# Altium schematic sheet Sch-QWA59_Power.SchDoc
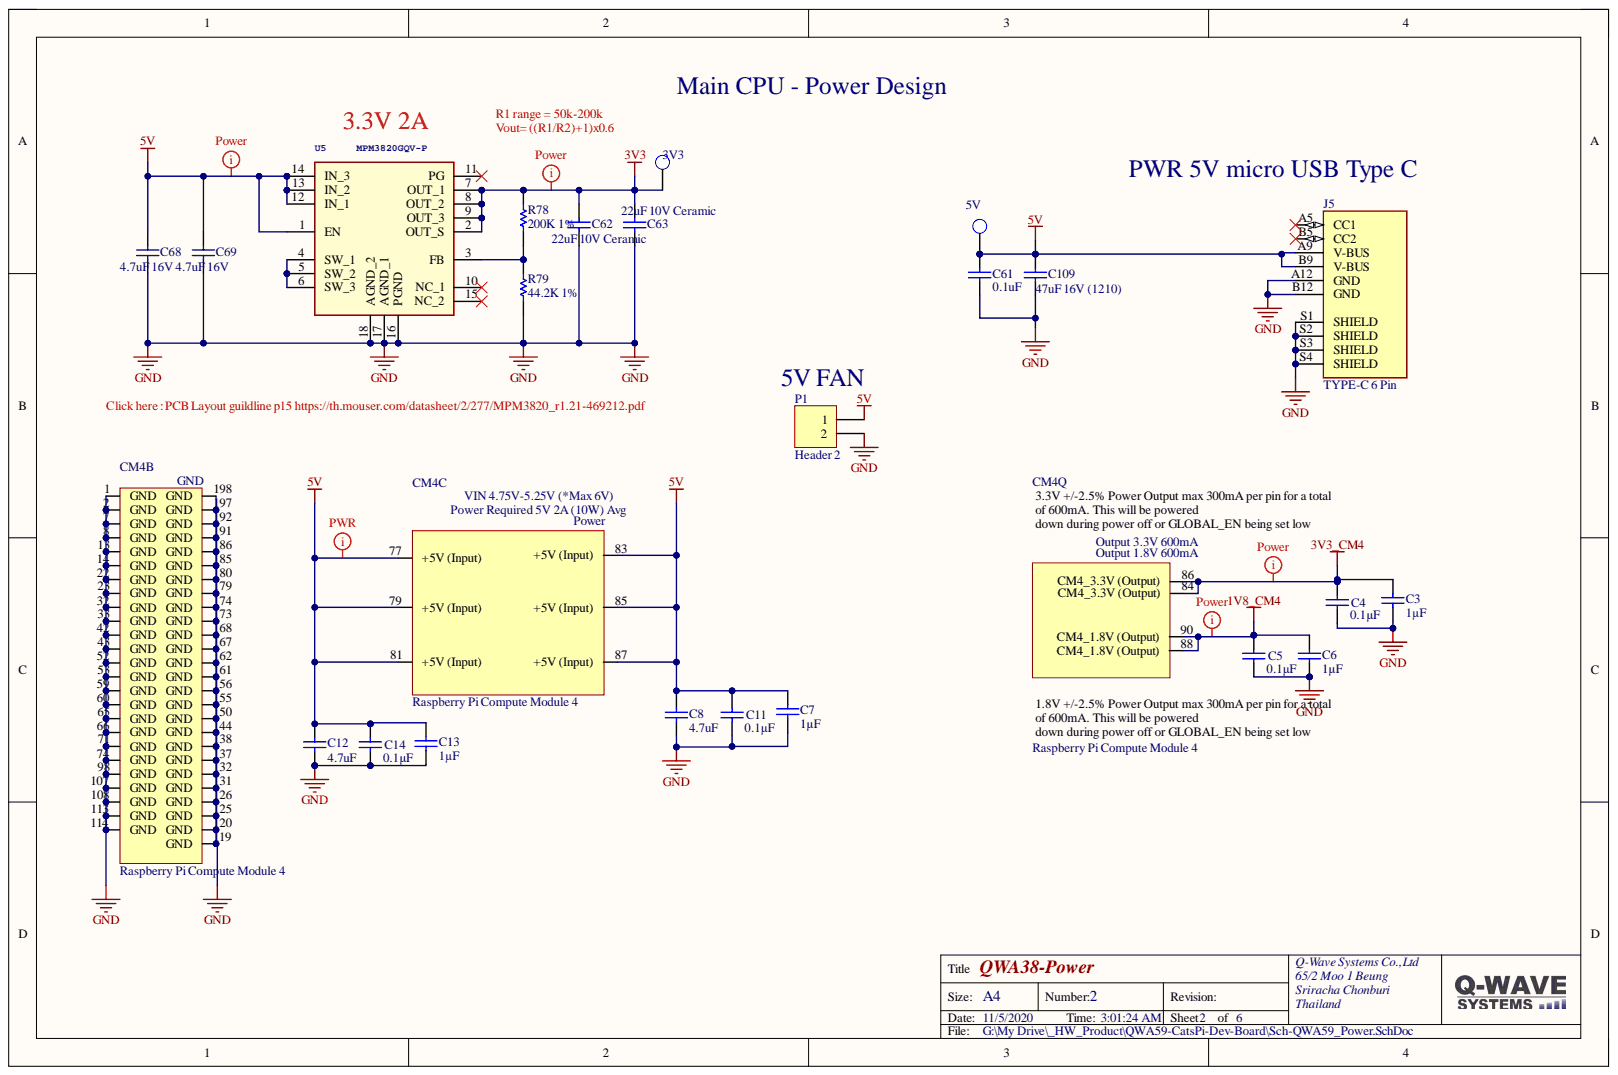
[diagram p0: Sch-QWA59_Power.SchDoc]

## Parts
3V3  3V3  [TEST_POINT]
5V  5V  [TEST_POINT]
C3  1µF  [CAP_0603]
C4  0.1µF  [CAP_0603]
C5  0.1µF  [CAP_0603]
C6  1µF  [CAP_0603]
C7  1µF  [CAP_0603]
C8  4.7uF  [CAP_0805]
C11  0.1µF  [CAP_0603]
C12  4.7uF  [CAP_0805]
C13  1µF  [CAP_0603]
C14  0.1µF  [CAP_0603]
C61  0.1uF  [CAP_0603]
C62  22uF 10V Ceramic  [CAP_0805]
C63  22uF 10V Ceramic  [CAP_0805]
C68  4.7uF 16V  [CAP_0805]
C69  4.7uF 16V  [CAP_0805]
C109  47uF 16V (1210)  [C 1210]
CM4  Raspberry Pi Compute Module 4  [CM4-F40C-100DS-0.4v_1.5mm_0mm]  (parts B,C,Q)
J5  TYPE-C 6 Pin  [TYPE-C-31-M-17]
P1  Header 2  [HDR1X2]
R78  200K 1%  [RES_0603]
R79  44.2K 1%  [RES_0603]
U5  MPM3820GQV-P  [MPM3820GQVP]

## Nets
1V8_CM4: C5.1 C6.2 CM4.88 CM4.90
3V3: 3V3.1 C62.1 C63.1 R78.2 U5.2(OUT_S) U5.7(OUT_1) U5.8(OUT_2) U5.9(OUT_3)
3V3_CM4: C3.2 C4.1 CM4.84 CM4.86
5V: 5V.1 C7.2 C8.1 C11.1 C12.1 C13.2 C14.1 C61.1 C68.1 C69.1 C109.1 CM4.77(+5V_(Input)) CM4.79(+5V_(Input)) CM4.81(+5V_(Input)) CM4.83(+5V_(Input)) CM4.85(+5V_(Input)) CM4.87(+5V_(Input)) J5.A9(V-BUS) J5.B9(V-BUS) P1.1 U5.1(EN) U5.12(IN_1) U5.13(IN_2) U5.14(IN_3)
GND: C3.1 C4.2 C5.2 C6.1 C7.1 C8.2 C11.2 C12.2 C13.1 C14.2 C61.2 C62.2 C63.2 C68.2 C69.2 C109.2 CM4.1(GND) CM4.2(GND) CM4.7(GND) CM4.8(GND) CM4.13(GND) CM4.14(GND) CM4.22(GND) CM4.23(GND) CM4.32(GND) CM4.33(GND) CM4.42(GND) CM4.43(GND) CM4.52(GND) CM4.53(GND) CM4.59(GND) CM4.60(GND) CM4.65(GND) CM4.66(GND) CM4.71(GND) CM4.74(GND) CM4.98(GND) CM4.107(GND) CM4.108(GND) CM4.113(GND) CM4.114(GND) CM4.119(GND) CM4.120(GND) CM4.125(GND) CM4.126(GND) CM4.131(GND) CM4.132(GND) CM4.137(GND) CM4.138(GND) CM4.144(GND) CM4.150(GND) CM4.155(GND) CM4.156(GND) CM4.161(GND) CM4.162(GND) CM4.167(GND) CM4.168(GND) CM4.173(GND) CM4.174(GND) CM4.179(GND) CM4.180(GND) CM4.185(GND) CM4.186(GND) CM4.191(GND) CM4.192(GND) CM4.197(GND) CM4.198(GND) J5.A12(GND) J5.B12(GND) J5.S1(SHIELD) J5.S2(SHIELD) J5.S3(SHIELD) J5.S4(SHIELD) P1.2 R79.1 U5.16(PGND) U5.17(AGND_1) U5.18(AGND_2)
NetR78_1: R78.1 R79.2 U5.3(FB)
NetU5_4: U5.4(SW_1) U5.5(SW_2) U5.6(SW_3)

Not connected: J5 A5(CC1) B5(CC2); U5 10(NC_1) 11(PG) 15(NC_2)

## Library data of the parts
3V3 5V = TEST_POINT: Test Point; Component Kind: Standard; Library Name: Misc.SCHLIB
C3 C6 C7 C13 = Cap Semi: 1µF ±5% 50V Ceramic Capacitor X7R 0603; MFG: KEMET; MFG P/N: C0603C104J5RACTU; Component Kind: Standard; Library Name: Miscellaneous Devices.IntLib; Signal Integrity: Cap Semi; Simulation: CAP
C4 C5 C11 C14 C61 = Cap Semi: 0.1µF ±5% 50V Ceramic Capacitor X7R 0603; MFG: KEMET; MFG P/N: C0603C104J5RACTU; Component Kind: Standard; Library Name: Miscellaneous Devices.IntLib; Signal Integrity: Cap Semi; Simulation: CAP
C8 C12 C68 C69 = Cap: 4.7µF ±10% 16V Ceramic Capacitor X5R 0805; MFG: KEMET; MFG P/N: C0603C475K8PACTU; Component Kind: Standard; Library Name: Miscellaneous Devices.IntLib; Signal Integrity: Cap; Simulation: CAP
C62 C63 = Cap: MFG: KEMET; MFG P/N: C0603C475K8PACTU; Component Kind: Standard; Description: 22uF 10V Ceramic; Library Name: Miscellaneous Devices.IntLib; Signal Integrity: Cap; Simulation: CAP
C109 = Cap: 47uF 16V Ceramic Capacitor X5R 1210; MFG: KEMET; MFG P/N: C0603C475K8PACTU; Component Kind: Standard; Library Name: Miscellaneous Devices.IntLib; Signal Integrity: Cap; Simulation: CAP
CM4 = CM4: Raspberry Pi Compute Module 4 by Amornthep Phunsin; Component Kind: Standard; Library Name: CM4.SchLib
J5 = TYPE-C-31-M-17: Manufacturer Korean Hroparts Elec  Mfr.Part # TYPE-C-31-M-17  LCSC Part # C283540; MF: {'manufacturer': u'CUI Devices'}; MP: UJC-HP-3-SMT-TR; Availability: Unavailable; Component Kind: Standard; Library Name: SnapEDAsch.SchLib; Package: None; Price: None
P1 = Header 2: Header, 2-Pin; Component Kind: Standard; LatestRevisionDate: 17-Jul-2002; LatestRevisionNote: Re-released for DXP Platform.; Library Name: Miscellaneous Connectors.IntLib; Signal Integrity: Connector; Publisher: Altium Limited
R78 R79 = Res Semi: Resistor 0603; Component Kind: Standard; Library Name: Miscellaneous Devices.IntLib; Signal Integrity: Res Semi; Simulation: RES
U5 = MPM3820GQV-P: MPM3820GQV-P VIN 6V, 1.2 MHz,  2A; Manufacturer_Name: Monolithic Power Systems (MPS); GateName_1: G$1; Component Kind: Standard; DeviceSetName: MPM3820GQV-P; Height: mm; Library Name: MPM3820GQV-P.SCHLIB; LibraryName: MPM3820GQV-P; Manufacturer_Part_Number: MPM3820GQV-P; Mouser_Part_Number: 946-MPM3820GQV-P; Supplier 1: Mouser; Supplier Part Number 1: 946-MPM3820GQV-P; SymbolName_1: MPM3820GQV-P
